# Altium schematic sheet Sensors.SchDoc(Sensors)
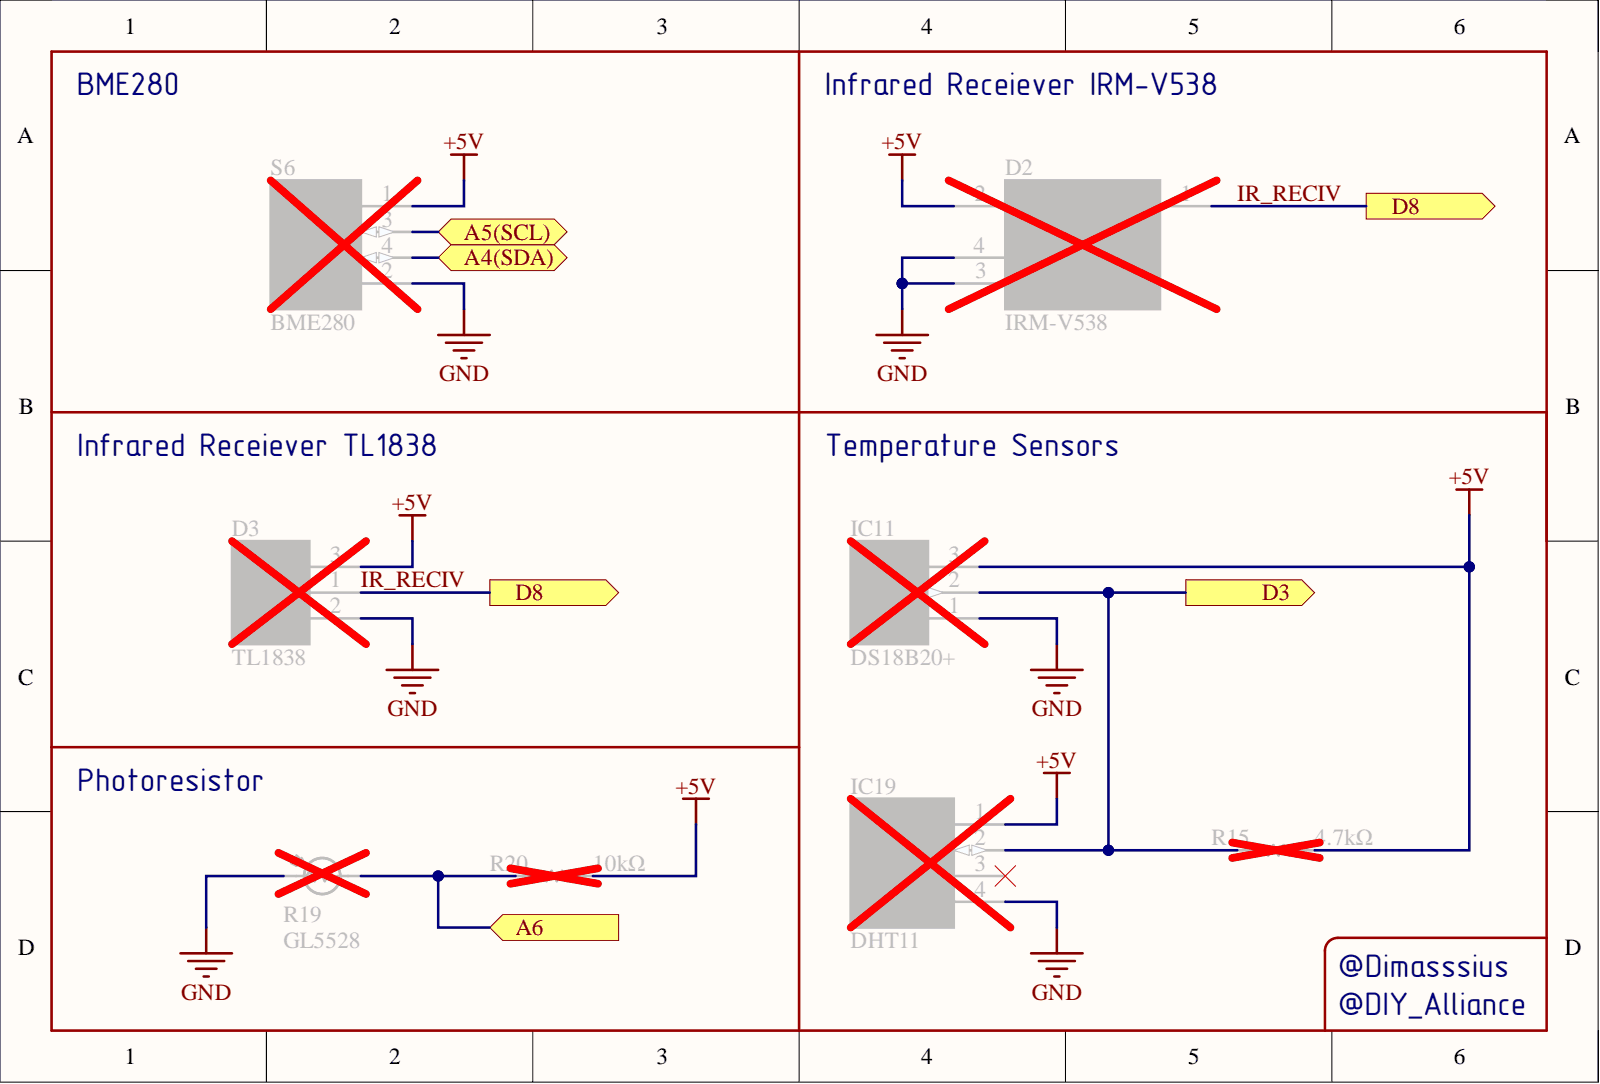
[diagram p0: Sensors.SchDoc]

## Parts
D2  IRM-V538  [IRM-V538]
D3  TL1838  [TSOP4856]
IC11  DS18B20+  [TO-92]
IC19  DHT11  [DHT11 - SMD]
R15  4.7kΩ  [RES_SMD_R0603_(M1608)_R0402A_(M1005A)]
R19  GL5528  [Photoresistor]
R20  10kΩ  [RES_SMD_R0603_(M1608)_R0402A_(M1005A)]
S6  BME280  [BME280_DEVBOARD]

## Ports
A4(SDA), A5(SCL), A6, D3, D8

## Nets
+5V: D2.2(VCC) D3.3 IC11.3 IC19.1(VDD) R15.2 R20.2 S6.1(VIN)
GND: D2.3(GND) D2.4(EH) D3.2 IC11.1 IC19.4(GND) R19.1 S6.2(GND)
A4(SDA): S6.4(SDA) ; port A4(SDA)
A5(SCL): S6.3(SCL) ; port A5(SCL)
A6: R19.2 R20.1 ; port A6
D3: IC11.2 IC19.2(DATA) R15.1 ; port D3
IR_RECIV: D2.1(OUT) D3.1 ; port D8

Not connected: IC19 3(NC)

## Library data of the parts
D2 = IRM-V538: Infrared Remote Receiver (IRM), 38kHz, 8m, 1.2mA, 2.7V~5.5V, Infrared Remote Receivers (IRM), ROHS; Manufacturer_Name: Texas Instruments; Manufacturer_Part_Number: SN74LVC2G04DBVR; Arrow Part Number: SN74LVC2G04DBVR; Arrow Price/Stock: https://www.arrow.com/en/products/sn74lvc2g04dbvr/texas-instruments?utm_currency=USD&region=nac; Component Kind: Standard; Datasheet Link: http://www.ti.com/lit/gpn/sn74lvc2g04; Height: 1.45mm; Library Name: SamacSys.SchLib; Mouser Part Number: 595-SN74LVC2G04DBVR; Mouser Price/Stock: https://www.mouser.co.uk/ProductDetail/Texas-Instruments/SN74LVC2G04DBVR?qs=HE9hdJajmeqXJJ9RII89dg%3D%3D; Value: IRM-V538
D3 = TL1838: Remote Receiver Sensor, 38.0kHz, 45m, Through Hole; Component Kind: Standard; Datasheet Link: http://www.mouser.com/datasheet/2/427/introductionpartnumbers-537189.pdf; Library Name: SamacSys.SchLib; Value: TL1838
IC11 = DS18B20+: Temperature Sensor, THD, TO-92, 3V~5.5V, -55℃~+125℃, ±0.5, Single Bus, RoHS; Component Kind: Standard; Datasheet Link: https://ms.componentsearchengine.com/Datasheets/2/DS18B20+.pdf; Height: 4.95mm; Library Name: SamacSys.SchLib; Value: DS18B20+
IC19 = DHT11: Temperature and Humidity Sensor, SIP-4, ROHS; Component Kind: Standard; Library Name: SamacSys.SchLib; Value: DHT11
R15 = RES_SMD_4.7KΩ: Resistor, Thick Film Resistor, SMD, 4.7kΩ, ±5%, Chip Resistor - Surface Mount, ROHS; Component Kind: Standard; Library Name: Resistors.SchLib; Value: 4.7kΩ
R19 = GL5528: Photoresistor, Through Hole, P=3mm, 10kΩ~20kΩ, 540nm, 1MΩ, Photoresistors, ROHS; Component Kind: Standard; Datasheet Link: https://datasheet.datasheetarchive.com/originals/distributors/Datasheets-DGA19/1465.pdf; Library Name: SamacSys.SchLib; Value: GL5528
R20 = RES_SMD_10KΩ: Resistor, Thick Film Resistor, SMD, 10kΩ, ±5%, Chip Resistor - Surface Mount, ROHS; Component Kind: Standard; Library Name: Resistors.SchLib; Value: 10kΩ
S6 = BME280_DEVBOARD: Development Board, LGA-8(2.5x2.5), Temperature and Humidity Sensor, ROHS; Component Kind: Standard; Library Name: SamacSys.SchLib; Value: BME280
